# Altium schematic sheet mcu.SchDoc
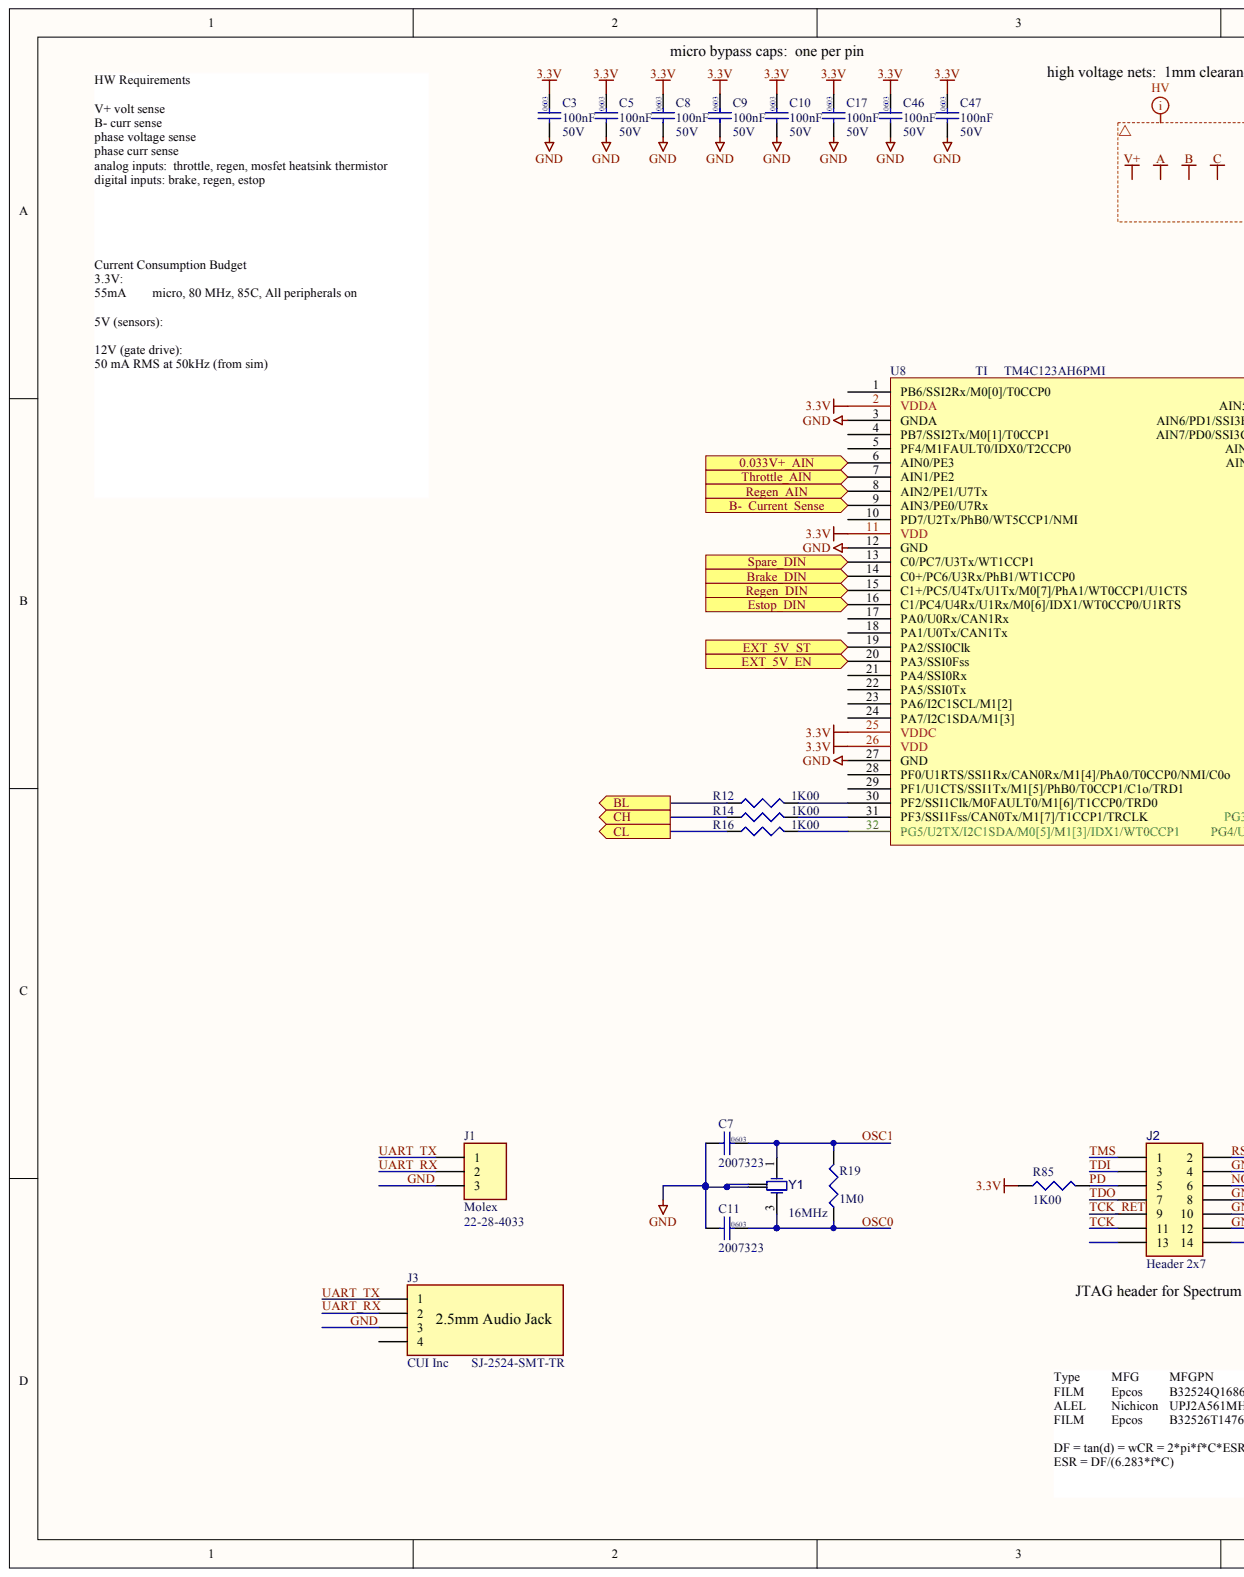
[diagram p0: mcu.SchDoc, left]
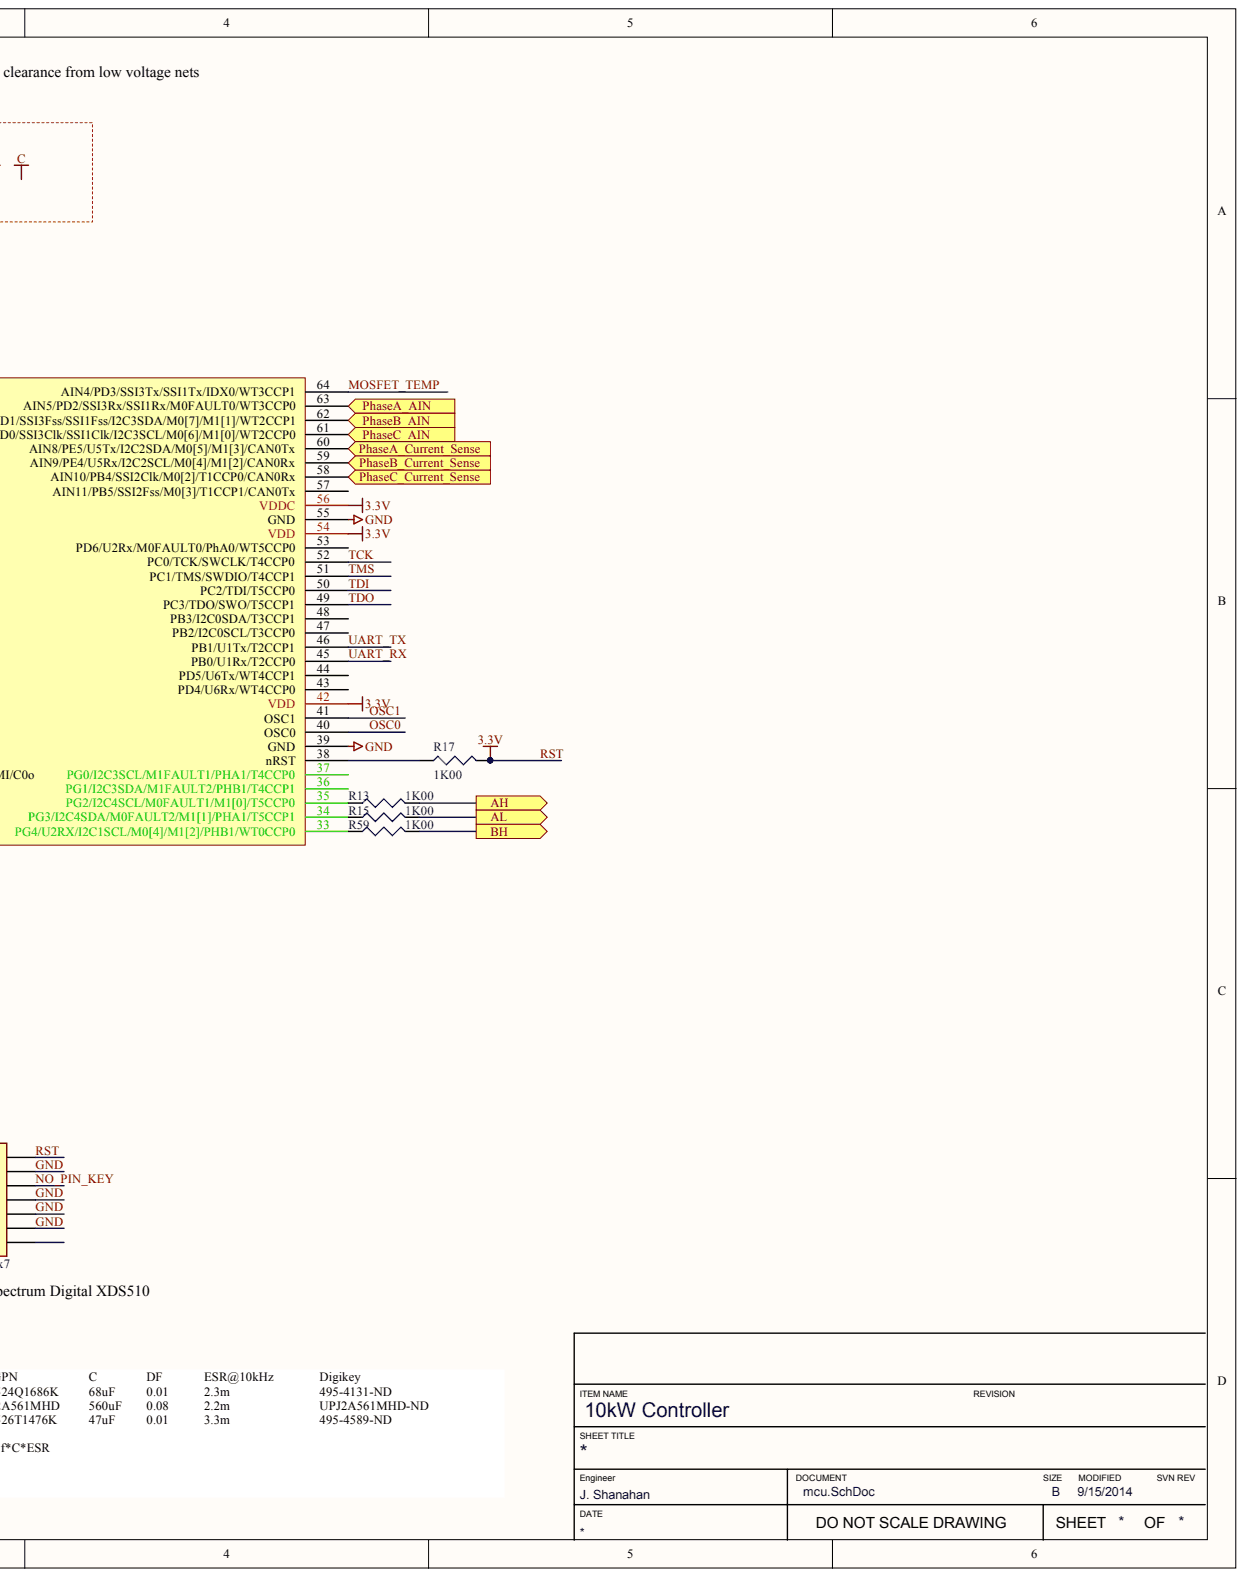
[diagram p1: mcu.SchDoc, right]

## Parts
C3  value 100nF  voltage: 50V  (hidden comment: 2005864)
C5  value 100nF  voltage: 50V  (hidden comment: 2005864)
C7  2007323
C8  value 100nF  voltage: 50V  (hidden comment: 2005864)
C9  value 100nF  voltage: 50V  (hidden comment: 2005864)
C10  value 100nF  voltage: 50V  (hidden comment: 2005864)
C11  2007323
C17  value 100nF  voltage: 50V  (hidden comment: 2005864)
C46  value 100nF  voltage: 50V  (hidden comment: 2005864)
C47  value 100nF  voltage: 50V  (hidden comment: 2005864)
J1  MFG1_PN: 22-28-4033  MFG1: Molex  (hidden comment: 2000380)
J2  Local_Name: Header 2x7  (hidden comment: 2001746)
J3  SJ-2524-SMT-TR  Manufacturer: CUI Inc
R12  1K00
R13  1K00
R14  1K00
R15  1K00
R16  1K00
R17  1K00
R19  value 1M0  (hidden comment: 2008073)
R59  1K00
R85  1K00
U8  TM4C123AH6PMI  MFG: TI
Y1  value 16MHz  (hidden comment: 1019427-00-A)

## Ports
0.033V+_AIN, AH, AL, B-_CURRENT_SENSE, BH, BL, BRAKE_DIN, CH, CL, ESTOP_DIN, EXT_5V_EN, EXT_5V_ST, PHASEA_AIN, PHASEA_CURRENT_SENSE, PHASEB_AIN, PHASEB_CURRENT_SENSE, PHASEC_AIN, PHASEC_CURRENT_SENSE, REGEN_AIN, REGEN_DIN, SPARE_DIN, THROTTLE_AIN

## Nets
0.033V+_AIN: U8.6(AIN0/PE3) ; port 0.033V+_AIN
AH: R13.2 ; port AH
AL: R15.2 ; port AL
BH: R59.2 ; port BH
BL: R12.2 ; port BL
CH: R14.2 ; port CH
CL: R16.2 ; port CL
EXT_5V_EN: U8.20(PA3/SSI0Fss) ; port EXT_5V_EN
EXT_5V_ST: U8.19(PA2/SSI0Clk) ; port EXT_5V_ST
GND: C3.2 C5.2 C7.2 C8.2 C9.2 C10.2 C11.2 C17.2 C46.2 C47.2 J1.3 J2.4 J2.8 J2.10 J2.12 J3.3 U8.3(GNDA) U8.12(GND) U8.27(GND) U8.39(GND) U8.55(GND) Y1.2 Y1.4
MOSFET_TEMP: U8.64(AIN4/PD3/SSI3Tx/SSI1Tx/IDX0/WT3CCP1)
NO_PIN_KEY: J2.6
OSC0: C11.1 R19.1 U8.40(OSC0) Y1.3
OSC1: C7.1 R19.2 U8.41(OSC1) Y1.1
PD: J2.5 R85.2
RST: C3.1 C5.1 C8.1 C9.1 C10.1 C17.1 C46.1 C47.1 J2.2 R17.2 R85.1 U8.2(VDDA) U8.11(VDD) U8.25(VDDC) U8.26(VDD) U8.42(VDD) U8.54(VDD) U8.56(VDDC)
TCK: J2.11 U8.52(PC0/TCK/SWCLK/T4CCP0)
TCK_RET: J2.9
TDI: J2.3 U8.50(PC2/TDI/T5CCP0)
TDO: J2.7 U8.49(PC3/TDO/SWO/T5CCP1)
TMS: J2.1 U8.51(PC1/TMS/SWDIO/T4CCP1)
UART_RX: J1.2 J3.2 U8.45(PB0/U1Rx/T2CCP0)
UART_TX: J1.1 J3.1 U8.46(PB1/U1Tx/T2CCP1)
NetR12_1: R12.1 U8.30(PF2/SSI1Clk/M0FAULT0/M1[6]/T1CCP0/TRD0)
NetR13_1: R13.1 U8.35(PG2/I2C4SCL/M0FAULT1/M1[0]/T5CCP0)
NetR14_1: R14.1 U8.31(PF3/SSI1Fss/CAN0Tx/M1[7]/T1CCP1/TRCLK)
NetR15_1: R15.1 U8.34(PG3/I2C4SDA/M0FAULT2/M1[1]/PHA1/T5CCP1)
NetR16_1: R16.1 U8.32(PG5/U2TX/I2C1SDA/M0[5]/M1[3]/IDX1/WT0CCP1)
NetR17_1: R17.1 U8.38(nRST)
NetR59_1: R59.1 U8.33(PG4/U2RX/I2C1SCL/M0[4]/M1[2]/PHB1/WT0CCP0)
NetU8_7: U8.7(AIN1/PE2) ; port THROTTLE_AIN
NetU8_8: U8.8(AIN2/PE1/U7Tx) ; port REGEN_AIN
NetU8_9: U8.9(AIN3/PE0/U7Rx) ; port B-_CURRENT_SENSE
NetU8_13: U8.13(C0/PC7/U3Tx/WT1CCP1) ; port SPARE_DIN
NetU8_14: U8.14(C0+/PC6/U3Rx/PhB1/WT1CCP0) ; port BRAKE_DIN
NetU8_15: U8.15(C1+/PC5/U4Tx/U1Tx/M0[7]/PhA1/WT0CCP1/U1CTS) ; port REGEN_DIN
NetU8_16: U8.16(C1/PC4/U4Rx/U1Rx/M0[6]/IDX1/WT0CCP0/U1RTS) ; port ESTOP_DIN
NetU8_58: U8.58(AIN10/PB4/SSI2Clk/M0[2]/T1CCP0/CAN0Rx) ; port PHASEC_CURRENT_SENSE
NetU8_59: U8.59(AIN9/PE4/U5Rx/I2C2SCL/M0[4]/M1[2]/CAN0Rx) ; port PHASEB_CURRENT_SENSE
NetU8_60: U8.60(AIN8/PE5/U5Tx/I2C2SDA/M0[5]/M1[3]/CAN0Tx) ; port PHASEA_CURRENT_SENSE
NetU8_61: U8.61(AIN7/PD0/SSI3Clk/SSI1Clk/I2C3SCL/M0[6]/M1[0]/WT2CCP0) ; port PHASEC_AIN
NetU8_62: U8.62(AIN6/PD1/SSI3Fss/SSI1Fss/I2C3SDA/M0[7]/M1[1]/WT2CCP1) ; port PHASEB_AIN
NetU8_63: U8.63(AIN5/PD2/SSI3Rx/SSI1Rx/M0FAULT0/WT3CCP0) ; port PHASEA_AIN
A:
B:
C:
N00077:
V+:

Not connected: J2 13 14; J3 4; U8 1(PB6/SSI2Rx/M0[0]/T0CCP0) 4(PB7/SSI2Tx/M0[1]/T0CCP1) 5(PF4/M1FAULT0/IDX0/T2CCP0) 10(PD7/U2Tx/PhB0/WT5CCP1/NMI) 17(PA0/U0Rx/CAN1Rx) 18(PA1/U0Tx/CAN1Tx) 21(PA4/SSI0Rx) 22(PA5/SSI0Tx) 23(PA6/I2C1SCL/M1[2]) 24(PA7/I2C1SDA/M1[3]) 28(PF0/U1RTS/SSI1Rx/CAN0Rx/M1[4]/PhA0/T0CCP0/NMI/C0o) 29(PF1/U1CTS/SSI1Tx/M1[5]/PhB0/T0CCP1/C1o/TRD1) 36(PG1/I2C3SDA/M1FAULT2/PHB1/T4CCP1) 37(PG0/I2C3SCL/M1FAULT1/PHA1/T4CCP0) 43(PD4/U6Rx/WT4CCP0) 44(PD5/U6Tx/WT4CCP1) 47(PB2/I2C0SCL/T3CCP0) 48(PB3/I2C0SDA/T3CCP1) 53(PD6/U2Rx/M0FAULT0/PhA0/WT5CCP0) 57(AIN11/PB5/SSI2Fss/M0[3]/T1CCP1/CAN0Tx)

## Library data of the parts
C3 C5 C8-C10 C17 C46 C47 = (no symbol name): tolerance: 10%; MFG1_PN: GCM188R71H104KA57D; MFG1: Murata; Load: NL; Detail Description: 100nF Capacitor, 50V, X7R, 10%, in 0603 package, Automotive Grade.; TPN: 01-006841-00; Enovia: 2005864; SAP: 2005864
C7 C11 = (no symbol name): tolerance: 5%; MFG1_PN: GCM1885C1H100JA16D; MFG1: Murata; Value: 10pF; Detail Description: 10pF Capacitor, 50V, COG, 5%, 0603 in Automotive Grade.; TPN: 01-007775-00; voltage: 50V; SAP: 2007323; Enovia: 2007323
J1 = (no symbol name): Local_Name: MOLEX 22-28-4033; LEVEL: 1; TPN: 01-000745-00; RoHS: YES; Supplier 1: Digi-Key; Supplier Part Number 1: WM6503-ND; SAP: 2000380
J2 = (no symbol name): TPN: 01-004051-00; MFG1: TYCO (AMP); MFG1_PN: 5-146252-7; LEVEL: 1; RoHS: YES; Supplier 1: Digi-Key; SAP: 2001746
J3 = (no symbol name): Connector Type: Phone Jack; Gender: Female; Signal Lines: Stereo; Plug/Mating Plug Diameter: 2.50mm (0.094", 3/32", Sub Mini, Miniature) - Headphone; Number of Positions/Contacts: 3 Conductors, 4 Contacts; Internal Switch(s): Single Switch; Shielding: Unshielded; Color - Contact: Black; Voltage - Rated: 12VDC; Current Rating: 1A; Supplier 1: Digi-Key; RoHS: RoHS Compliant; Category: Connectors, Interconnects; Stock 1: 107367; Unit Price: 1.28; Packaging: Cut Tape (CT); Mounting Type: Surface Mount, Right Angle; Termination: Solder; Features: -; Contact Material: Copper Alloy; Contact Material - Plating: Silver; Housing Material: Plastic; Housing Color: Black; Operating Temperature: -25°C ~ 85°C; ComponentLink1URL: http://www.cui.com/product/resource/digikeypdf/sj-252x-smt_series.pdf; ComponentLink1Description: http://www.cui.com/product/resource/digikeypdf/sj-252x-smt_series.pdf; Supplier Part Number 1: CP-2524SJCT-ND; Manufacturer Part Number: SJ-2524-SMT-TR
R12-R17 R59 R85 = (no symbol name): Tolerance: 1%; POWER: 0.10W; MFG1_PN: CRCW06031K00FKEA; MFG1: Vishay; Revision: July-2002: Re-released for DXP Platform.; Value: 1K00
R19 = (no symbol name): Tolerance: 1%; POWER: 0.0625W; MFG1_PN: CRCW04021M00FKED; MFG1: Vishay; Load: NL; Detail Description: Resistor, 1M0, 0402 package, automotive grade.; TPN: 01-008779-00; Revision: July-2002: Re-released for DXP Platform.; RoHS: YES; Enovia: 2008073; SAP: 2008073
Y1 = (no symbol name): MFG1_PN: NX3225SA 16MHZ AT-W; MFG1: NDK; Load: NL; Detail Description: NDK crystal, 16MHz, 50ppm, 8pF load capacitance, Automotive Grade.; Cl: 8pF; TPN: 1019427-00-A; RoHS: YES; SAP: 1019427-00-A
U8 = (no symbol name): MFGPN: TM4C123AH6PMI
